# Altium schematic sheet IO.SchDoc(IO)
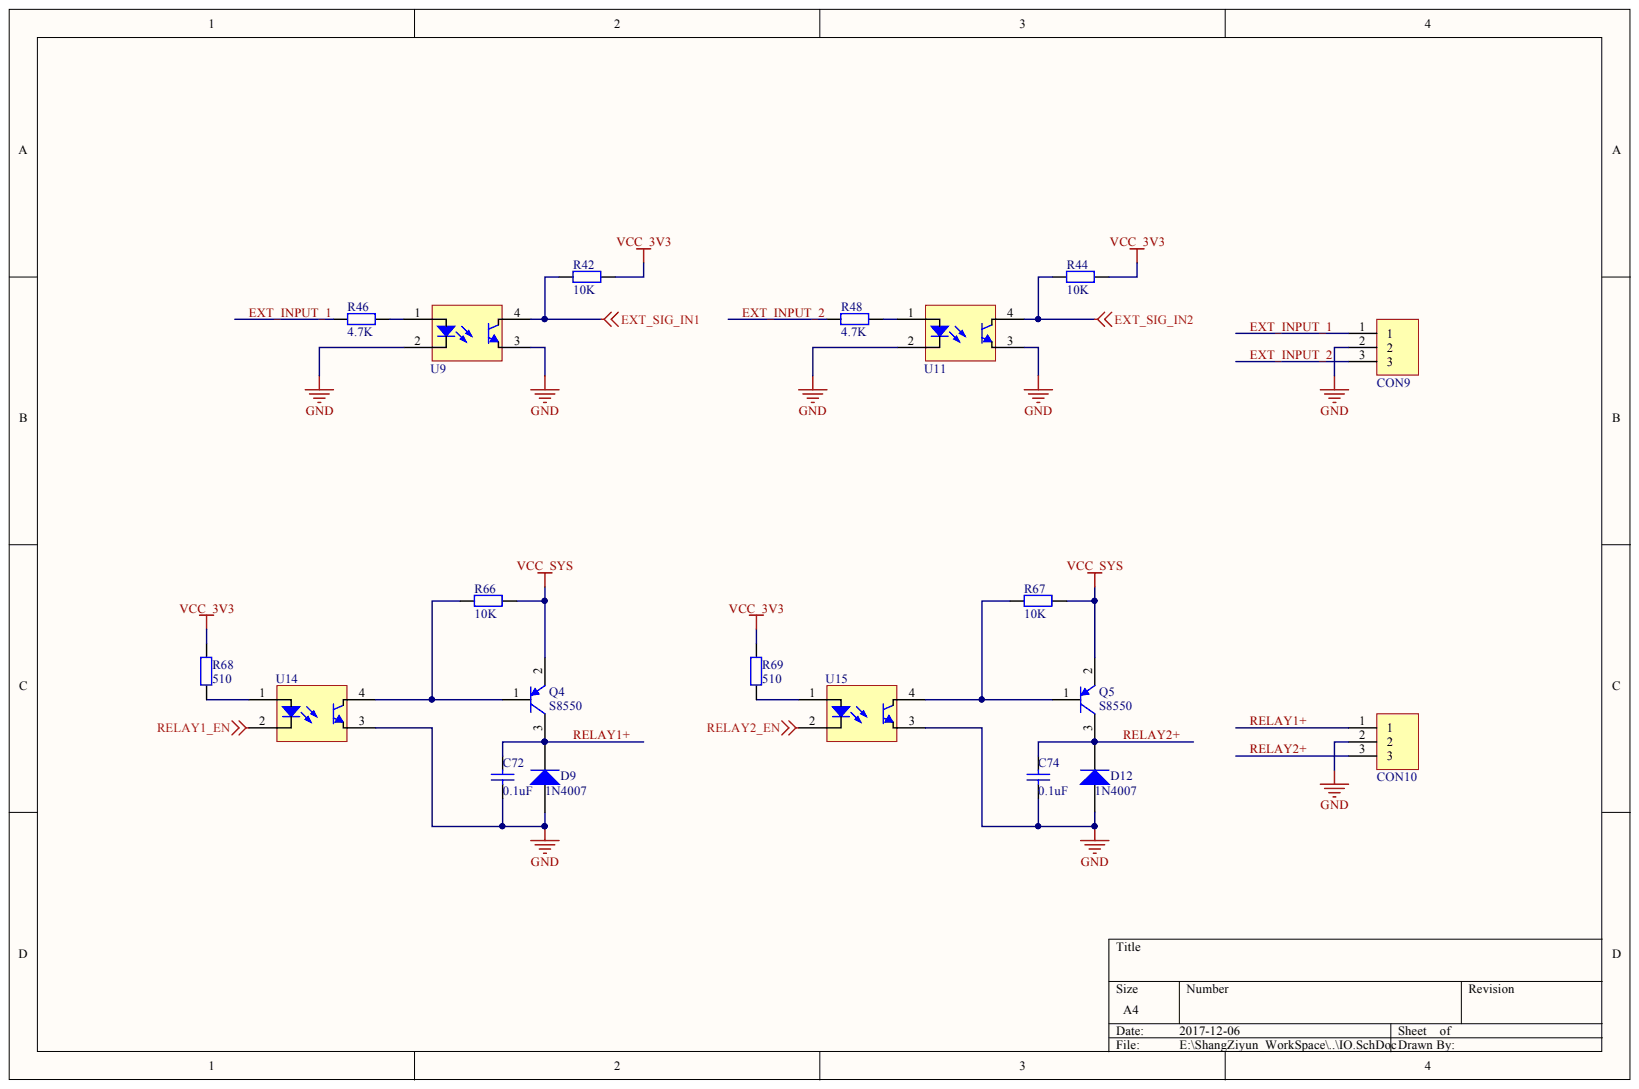
[diagram p0: IO.SchDoc]

## Parts
C72  value 0.1uF  [0603C]  (hidden comment: Capacitor)
C74  value 0.1uF  [0603C]  (hidden comment: Capacitor)
CON9  CONNECTOR_3PIN  [HT5.08-3W]  (value not drawn)
CON10  CONNECTOR_3PIN  [HT5.08-3W]  (value not drawn)
D9  1N4007  [DO214AC(SMA)]
D12  1N4007  [DO214AC(SMA)]
Q4  S8550  [SOT23]
Q5  S8550  [SOT23]
R42  value 10K  [L0603]  (hidden comment: Resistor)
R44  value 10K  [L0603]  (hidden comment: Resistor)
R46  value 4.7K  [L0603]  (hidden comment: Resistor)
R48  value 4.7K  [L0603]  (hidden comment: Resistor)
R66  value 10K  [L0603]  (hidden comment: Resistor)
R67  value 10K  [L0603]  (hidden comment: Resistor)
R68  value 510  [L0603]  (hidden comment: Resistor)
R69  value 510  [L0603]  (hidden comment: Resistor)
U9  TLP521-1  [SOP4-2.54]  (value not drawn)
U11  TLP521-1  [SOP4-2.54]  (value not drawn)
U14  TLP521-1  [SOP4-2.54]  (value not drawn)
U15  TLP521-1  [SOP4-2.54]  (value not drawn)

## Nets
EXT_SIG_IN1: R42.1 U9.4
EXT_SIG_IN2: R44.1 U11.4
GND: C72.2 C74.2 CON9.2 CON10.2 D9.1 D12.1 U9.2 U9.3 U11.2 U11.3 U14.3 U15.3
RELAY1_EN: U14.2
RELAY2_EN: U15.2
VCC_3V3: R42.2 R44.2 R68.2 R69.2
VCC_SYS: Q4.2 Q5.2 R66.2 R67.2
EXT_INPUT_1: CON9.1 R46.1
EXT_INPUT_2: CON9.3 R48.1
RELAY1+: C72.1 CON10.1 D9.2 Q4.3
RELAY2+: C74.1 CON10.3 D12.2 Q5.3
NetQ4_1: Q4.1 R66.1 U14.4
NetQ5_1: Q5.1 R67.1 U15.4
NetR46_2: R46.2 U9.1
NetR48_2: R48.2 U11.1
NetR68_1: R68.1 U14.1
NetR69_1: R69.1 U15.1

## Library data of the parts
C72 C74 = Cap: Component Kind: Standard; Description: Capacitor; LatestRevisionDate: 17-Jul-2002; LatestRevisionNote: Re-released for DXP Platform.; Library Name: Miscellaneous Devices.IntLib; PackageDescription: Radial Cap, Thru-Hole; 2 Leads; 0.3 in Pin Spacing; PackageReference: RAD-0.3; Published: 8-Jun-2000; Signal Integrity: Cap; Simulation: CAP; Publisher: Altium Limited
CON9 CON10 = CONNECTOR_3PIN: Value: HT5.08-3W; Component Kind: Standard; Library Name: MyLib.SCHLIB
D9 D12 = Diode 1N4007: 1 Amp General Purpose Rectifier; Code_JEDEC: DO-204-AL; Component Kind: Standard; ComponentLink1Description: Manufacturer Link; ComponentLink1URL: http://www.fairchildsemi.com/; ComponentLink2Description: Datasheet; ComponentLink2URL: http://www.fairchildsemi.com/ds/1N%2F1N4001.pdf; DatasheetDocument: 1998; LatestRevisionDate: 17-Jul-2002; LatestRevisionNote: Re-released for DXP Platform.; Library Name: Miscellaneous Devices.IntLib; PackageDocument: Aug-1999; PackageReference: DO-41; Published: 8-Jun-2000; Signal Integrity: 1N4007; Simulation: 1N4007; Value: 1N4007; Publisher: Altium Limited
Q4 Q5 = PNP: Component Kind: Standard; Value: S8550; Library Name: LNG.SCHLIB
R42 R44 R46 R48 R66-R69 = Res: Component Kind: Standard; Library Name: LNG.SCHLIB
U9 U11 = TLP521-1: Value: PC817; Component Kind: Standard; Library Name: LNG.SCHLIB
U14 U15 = TLP521-1: Value: TLP521-1，光耦; Component Kind: Standard; Library Name: LNG.SCHLIB
